# Altium schematic sheet PLD_Connectors.SchDoc
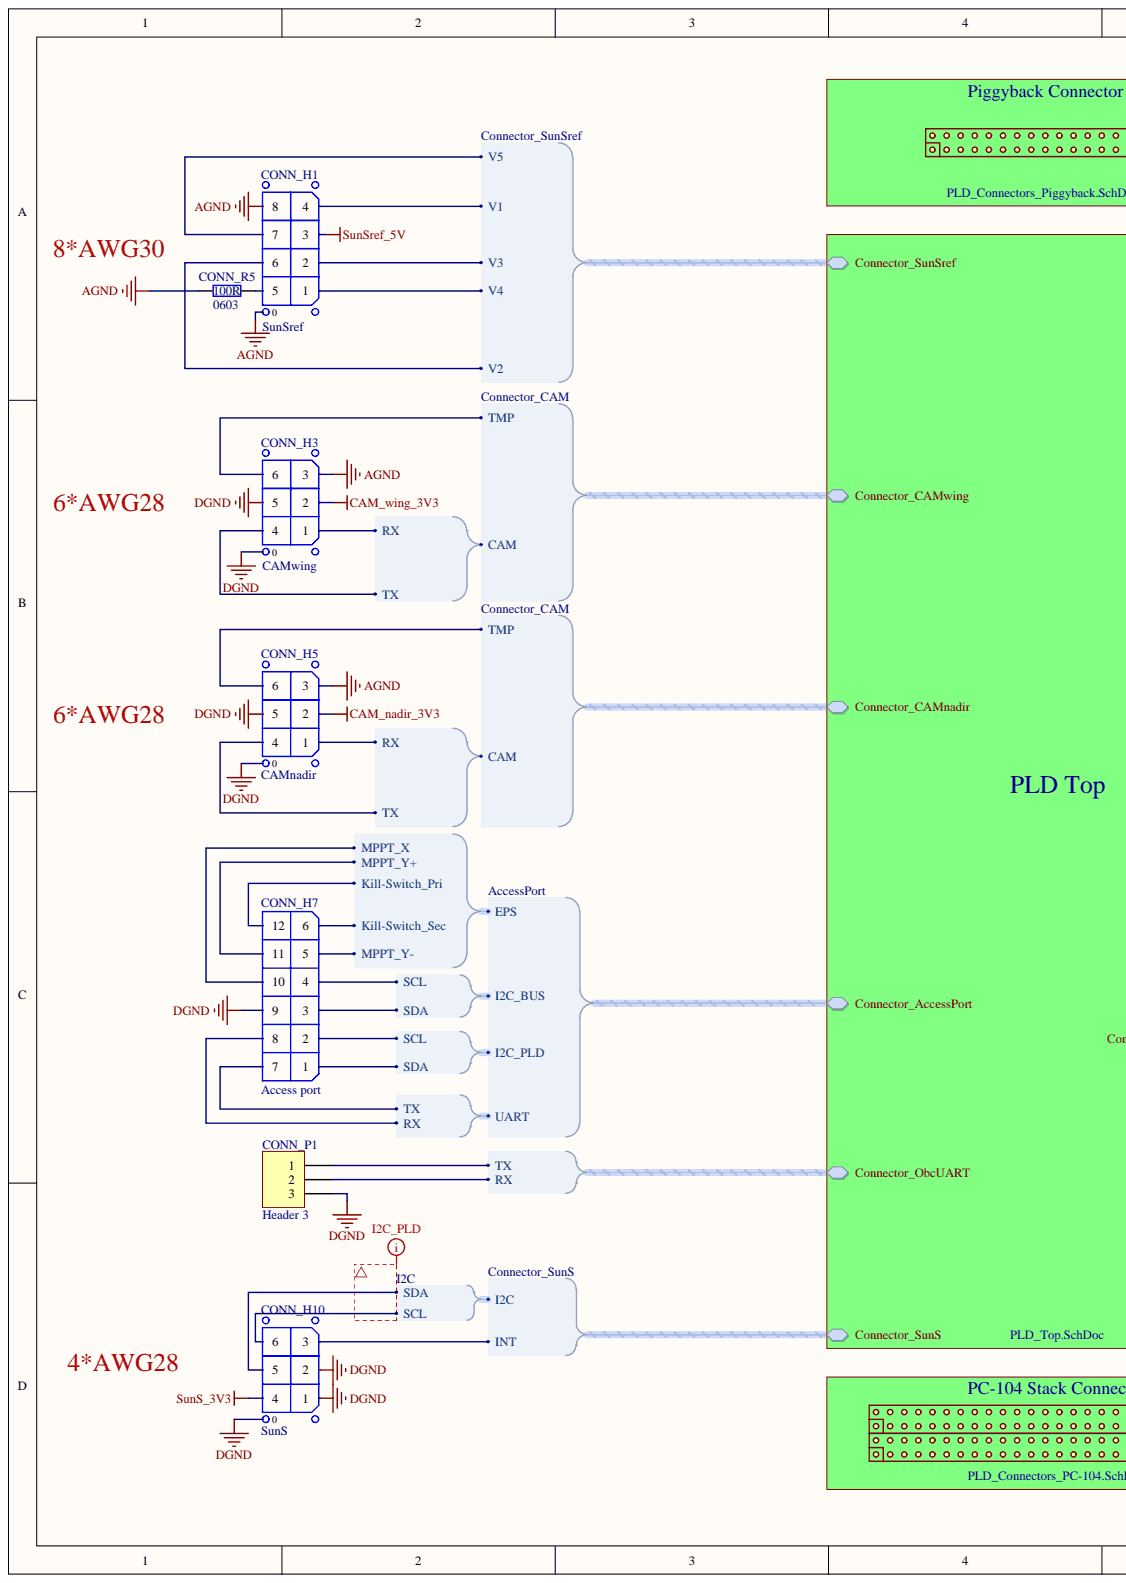
[diagram p0: PLD_Connectors.SchDoc, left]
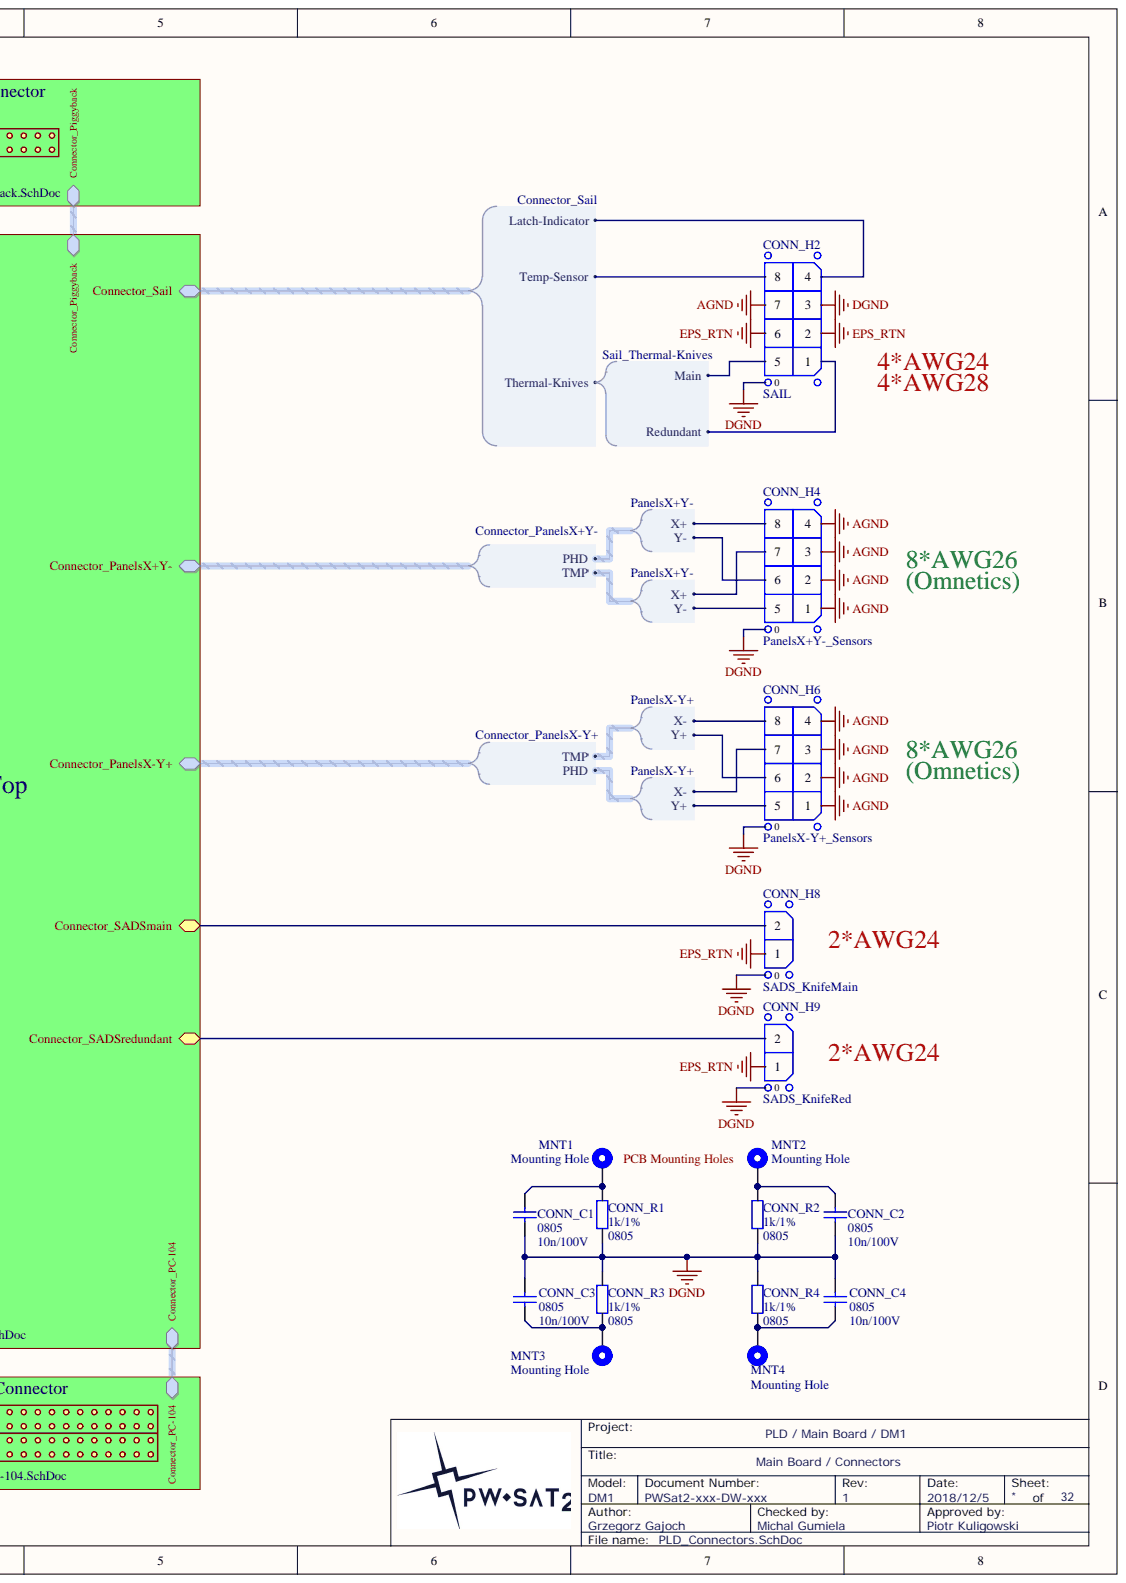
[diagram p1: PLD_Connectors.SchDoc, right]

## Parts
CONN_C1  10n/100V  Package: 0805  [SMD_Capacitor_0805]
CONN_C2  10n/100V  Package: 0805  [SMD_Capacitor_0805]
CONN_C3  10n/100V  Package: 0805  [SMD_Capacitor_0805]
CONN_C4  10n/100V  Package: 0805  [SMD_Capacitor_0805]
CONN_H1  SunSref  [Harwin_Datamate_L-Tek_M80-8670805]
CONN_H2  SAIL  [Harwin_Datamate_L-Tek_M80-8670805]
CONN_H3  CAMwing  [Harwin_Datamate_L-Tek_M80-8670605]
CONN_H4  PanelsX+Y-_Sensors  [Harwin_Datamate_L-Tek_M80-8670805]
CONN_H5  CAMnadir  [Harwin_Datamate_L-Tek_M80-8670605]
CONN_H6  PanelsX-Y+_Sensors  [Harwin_Datamate_L-Tek_M80-8670805]
CONN_H7  Access port  [Harwin_Datamate_L-Tek_M80-8411242_W/O_RETAINING_STRAP]
CONN_H8  SADS_KnifeMain  [Harwin_Datamate_L-Tek_M80-8770222]
CONN_H9  SADS_KnifeRed  [Harwin_Datamate_L-Tek_M80-8770222]
CONN_H10  SunS  [Harwin_Datamate_L-Tek_M80-8670605]
CONN_P1  Header 3  [HEADER 1X3 FEMALE]
CONN_R1  1k/1%  Package: 0805  [SMD_Resistor_0805]
CONN_R2  1k/1%  Package: 0805  [SMD_Resistor_0805]
CONN_R3  1k/1%  Package: 0805  [SMD_Resistor_0805]
CONN_R4  1k/1%  Package: 0805  [SMD_Resistor_0805]
CONN_R5  100R  Package: 0603  [SMD_Resistor_0603]
MNT1  Mounting Hole  [PC-104_PcbMntHole_M3]
MNT2  Mounting Hole  [PC-104_PcbMntHole_M3]
MNT3  Mounting Hole  [PC-104_PcbMntHole_M3]
MNT4  Mounting Hole  [PC-104_PcbMntHole_M3]

## Nets
AGND: CONN_H1.0 CONN_H1.8 CONN_H2.7 CONN_H3.3 CONN_H4.1 CONN_H4.2 CONN_H4.3 CONN_H4.4 CONN_H5.3 CONN_H6.1 CONN_H6.2 CONN_H6.3 CONN_H6.4 CONN_R5.1
CAM_nadir_3V3: CONN_H5.2
CAM_wing_3V3: CONN_H3.2
DGND: CONN_C1.1 CONN_C2.1 CONN_C3.2 CONN_C4.2 CONN_H2.0 CONN_H2.3 CONN_H3.0 CONN_H3.5 CONN_H4.0 CONN_H5.0 CONN_H5.5 CONN_H6.0 CONN_H7.9 CONN_H8.0 CONN_H9.0 CONN_H10.0 CONN_H10.1 CONN_H10.2 CONN_P1.3 CONN_R1.1 CONN_R2.1 CONN_R3.2 CONN_R4.2
EPS_RTN: CONN_H2.2 CONN_H2.6 CONN_H8.1 CONN_H9.1
SunS_3V3: CONN_H10.4
SunSref_5V: CONN_H1.3
NetCONN_C1_2: CONN_C1.2 CONN_R1.2 MNT1.1
NetCONN_C2_2: CONN_C2.2 CONN_R2.2 MNT2.1
NetCONN_C3_1: CONN_C3.1 CONN_R3.1 MNT3.1
NetCONN_C4_1: CONN_C4.1 CONN_R4.1 MNT4.1
NetCONN_H1_1: CONN_H1.1
NetCONN_H1_2: CONN_H1.2
NetCONN_H1_4: CONN_H1.4
NetCONN_H1_5: CONN_H1.5 CONN_R5.2
NetCONN_H1_6: CONN_H1.6
NetCONN_H1_7: CONN_H1.7
NetCONN_H2_1: CONN_H2.1
NetCONN_H2_4: CONN_H2.4
NetCONN_H2_5: CONN_H2.5
NetCONN_H2_8: CONN_H2.8
NetCONN_H3_1: CONN_H3.1
NetCONN_H3_4: CONN_H3.4
NetCONN_H3_6: CONN_H3.6
NetCONN_H4_5: CONN_H4.5
NetCONN_H4_6: CONN_H4.6
NetCONN_H4_7: CONN_H4.7
NetCONN_H4_8: CONN_H4.8
NetCONN_H5_1: CONN_H5.1
NetCONN_H5_4: CONN_H5.4
NetCONN_H5_6: CONN_H5.6
NetCONN_H6_5: CONN_H6.5
NetCONN_H6_6: CONN_H6.6
NetCONN_H6_7: CONN_H6.7
NetCONN_H6_8: CONN_H6.8
NetCONN_H7_1: CONN_H7.1
NetCONN_H7_2: CONN_H7.2
NetCONN_H7_3: CONN_H7.3
NetCONN_H7_4: CONN_H7.4
NetCONN_H7_5: CONN_H7.5
NetCONN_H7_6: CONN_H7.6
NetCONN_H7_7: CONN_H7.7
NetCONN_H7_8: CONN_H7.8
NetCONN_H7_10: CONN_H7.10
NetCONN_H7_11: CONN_H7.11
NetCONN_H7_12: CONN_H7.12
NetCONN_H8_2: CONN_H8.2
NetCONN_H9_2: CONN_H9.2
NetCONN_H10_3: CONN_H10.3
NetCONN_H10_5: CONN_H10.5
NetCONN_H10_6: CONN_H10.6
NetCONN_P1_1: CONN_P1.1
NetCONN_P1_2: CONN_P1.2
Connector_PC-104.EPS.Kill-Switch_Pri:
Connector_PC-104.EPS.Kill-Switch_Sec:
Connector_PC-104.EPS.MPPT_X:
Connector_PC-104.EPS.MPPT_Y+:
Connector_PC-104.EPS.MPPT_Y-:
Connector_PC-104.I2C_BUS.SCL:
Connector_PC-104.I2C_BUS.SDA:
Connector_PC-104.I2C_Payload.SCL:
Connector_PC-104.I2C_Payload.SDA:
Connector_PC-104.SADS.Main:
Connector_PC-104.SADS.Redundant:
Connector_PC-104.Sail_Thermal-Knives.Main:
Connector_PC-104.Sail_Thermal-Knives.Redundant:
Connector_Piggyback.GPIO.CAM_SELECT:
Connector_Piggyback.GPIO.OBC_STATUS_LED:
Connector_Piggyback.GPIO.PLD_INT:
Connector_Piggyback.GPIO.SAIL_INDICATOR:
Connector_Piggyback.GPIO.SUNS_INT:
Connector_Piggyback.MEMORY.FLASH1_~{CS}:
Connector_Piggyback.MEMORY.FLASH2_~{CS}:
Connector_Piggyback.MEMORY.FLASH3_~{CS}:
Connector_Piggyback.MEMORY.FRAM1_~{CS}:
Connector_Piggyback.MEMORY.FRAM2_~{CS}:
Connector_Piggyback.MEMORY.FRAM3_~{CS}:
Connector_Piggyback.MEMORY.SPI.MISO:
Connector_Piggyback.MEMORY.SPI.MOSI:
Connector_Piggyback.MEMORY.SPI.SCK:
Connector_Piggyback.UART.RX:
Connector_Piggyback.UART.TX:
N00184:

## Library data of the parts
CONN_C1-CONN_C4 = Cer_C0805T104K1RCLTU: Ceramic Cap Kemet COTS 100n/100V X7R 0805; Component Kind: Standard; Library Name: capacitors.IntLib; Manufacturer: Kemet; ManufacturerPartNumber: C0805T104K1RCLTU; Value: 10n/100V
CONN_H1 CONN_H2 CONN_H4 CONN_H6 = Harwin_Datamate_L-Tek_2x4pin: Harwin DataMate L-Tek, 2mm pitch, 2x4pin.; Value: Harwin DataMate L-Tek 2x4pin; Component Kind: Standard; Library Name: connectors_printed-circuit-board.IntLib
CONN_H3 CONN_H5 CONN_H10 = Harwin_Datamate_L-Tek_2x3pin: Harwin DataMate L-Tek, 2mm pitch, 2x3pin.; Value: Harwin DataMate L-Tek 2x3pin; Component Kind: Standard; Library Name: connectors_printed-circuit-board.IntLib
CONN_H7 = Harwin_Datamate_L-Tek_2x6pin_W/O_RETAINING_STRAP: Harwin DataMate L-Tek, 2mm pitch, 2x6pin.; Value: Harwin DataMate L-Tek 2x6pin; Component Kind: Standard; Library Name: connectors_printed-circuit-board.IntLib
CONN_H8 CONN_H9 = Harwin_Datamate_L-Tek_1x2pin: Harwin DataMate L-Tek, 2mm pitch, 1x2pin.; Value: Harwin DataMate L-Tek 1x2pin; Component Kind: Standard; Library Name: connectors_printed-circuit-board.IntLib
CONN_P1 = Header 3: Header, 3-Pin; Component Kind: Standard; LatestRevisionDate: 17-Jul-2002; LatestRevisionNote: Re-released for DXP Platform.; Library Name: connectors_printed-circuit-board.IntLib; Publisher: Altium Limited
CONN_R1-CONN_R4 = CRCW08051K00FKxxxx: 1k/1% Vishay CRCW 0805 Resistor. 125mW, 100ppm, 150V.; Component Kind: Standard; Library Name: resistors.IntLib; Manufacturer: Vishay; ManufacturerPartNumber: CRCW08051K00FKxxxx; Value: 1k/1%
CONN_R5 = Res: Component Kind: Standard; Library Name: resistors.IntLib; Value: 100R
MNT1-MNT4 = MntHole: PCB Mounting Hole; Component Kind: Standard; Value: Mounting Hole; Library Name: connectors_printed-circuit-board.IntLib
